# Altium schematic sheet PowerDistribution.SchDoc (continued)

## Library data of the parts
C9 C10 C17 = CMP-1036-04410-2: CAP CER 0.1UF 25V X7R 0805; Manufacturer: Yageo; Manufacturer Part Number: CC0805KRX7R8BB104; Capacitance: 100 nF; Insulation Resistance: 100GR; Material: Ceramic; Tolerance: 0.1; Value: 100 nF; Automotive: No; Case Code (Imperial): 0805; Case Code (Metric): 2012; Case/Package: 0805; Category: Cap; Component Kind: Standard; ComponentLink1Description: https://datasheet.ciiva.com/8034/70765-8034031.pdf?src-supplier=Element14; ComponentLink1URL: https://datasheet.ciiva.com/8034/70765-8034031.pdf?src-supplier=Element14; ComponentLink2Description: Datasheet; ComponentLink2URL: http://www.yageo.com/documents/recent/UPY-GPHC_Y5V_6.3V-to-50V_8.pdf; ComponentLink3Description: https://datasheet.ciiva.com/28450/1489800-28450789.pdf?src-supplier=Element14; ComponentLink3URL: https://datasheet.ciiva.com/28450/1489800-28450789.pdf?src-supplier=Element14; ComponentLink4Description: https://datasheet.ciiva.com/46430/1850775-46430166.pdf?src-supplier=Element14; ComponentLink4URL: https://datasheet.ciiva.com/46430/1850775-46430166.pdf?src-supplier=Element14; ComponentLink5Description: https://datasheet.ciiva.com/145/mlccorderingcode-145008.pdf?src-supplier=Digi-Key; ComponentLink5URL: https://datasheet.ciiva.com/145/mlccorderingcode-145008.pdf?src-supplier=Digi-Key; ComponentLink6Description: https://datasheet.ciiva.com/145/mlccorderingcode-145007.pdf?src-supplier=Digi-Key; ComponentLink6URL: https://datasheet.ciiva.com/145/mlccorderingcode-145007.pdf?src-supplier=Digi-Key; ComponentLink7Description: https://datasheet.ciiva.com/145/mlccorderingcode-145009.pdf?src-supplier=Digi-Key; ComponentLink7URL: https://datasheet.ciiva.com/145/mlccorderingcode-145009.pdf?src-supplier=Digi-Key; ComponentLink8Description: https://datasheet.ciiva.com/8034/1784427-8034030.pdf?src-supplier=Element14; ComponentLink8URL: https://datasheet.ciiva.com/8034/1784427-8034030.pdf?src-supplier=Element14; Depth: 1.25mm; Device Class L1: Passive Components; Device Class L2: Capacitors; Device Class L3: Ceramic Capacitors; Dielectric: X7R; Digikey Description: CAP CER 0.1UF 25V X7R 0805; Footprint Url: http://www.yageo.com/exep/pages/download/literatures/UPY-C_GEN_24.pdf; Halogen Free: Halogen Free; Height: 0.95mm; Ipc Land Pattern Name: CAPC200125X85; Lead Free: Yes; Length: 2mm; Library Name: Altium Content Vault; Max Operating Temperature: 125°C; Min Operating Temperature: -55°C; Mount: Surface Mount; Mouser Description: Multilayer Ceramic Capacitors MLCC - SMD/SMT 100nF 25V X7R 10%; Number of Pins: 2; Octopart: https://octopart.com/cc0805krx7r8bb104-yageo-39467766; Package: CC0805-0.85; Package Quantity: 4000; Packaging: Tape and Reel; Pricing 1: 1=0.11000, 10=0.07700, 50=0.04380, 100=0.03620, 500=0.02542, 1000=0.02104 (USD); Radiation Hardening: No; Reach SVHC Compliant: Yes; Resistor Type: Ceramic; RoHS: TRUE; RoHS Compliant: Yes; Series: CC; Stock 1: 1013369; Supplier 1: Digi-Key; Supplier Part Number 1: 311-1141-1-ND; Temperature: -30°C to +85°C; Temperature Characteristic: X7R; Temperature Range High: +85°C; Temperature Range Low: -30°C; Termination: SMD/SMT; Thickness: 0.033inch; Voltage Rating: 25 V; Voltage Rating (DC): 25V; Width: 1.25mm
C11 C12 C18 = CMP-08246-001076-1: Cap Ceramic 2.2uF 35V X7R 10% Pad SMD 0805 +125°C Automotive T/R; Manufacturer: TDK; Manufacturer Part Number: CGA4J1X7R1V225K125AC; Capacitance: 2.2uF; Case Code (Imperial): 0805; Case Code (Metric): 2012; Case/Package: 0805; Category: Ceramic Capacitors; Component Kind: Standard; ComponentLink1Description: https://datasheet.ciiva.com/24219/cga4j1x7r1v225k125ac-265990-24219578.pdf?src-supplier=Mouser; ComponentLink1URL: https://datasheet.ciiva.com/24219/cga4j1x7r1v225k125ac-265990-24219578.pdf?src-supplier=Mouser; Composition: Ceramic; Depth: 1.25mm; Dielectric: X7R; Height: 1.25mm; Length: 2mm; Library Name: Altium Content Vault; Max Operating Temperature: 125°C; Min Operating Temperature: -55°C; Mount: Surface Mount; Package Quantity: 1; Packaging: Tape and Reel; Pricing 1: 1=0.11700, 2000=0.11300, 4000=0.10900, 8000=0.10500, 12000=0.10000 (USD); Ratings: AEC-Q200; REACH SVHC: No SVHC; Reach SVHC Compliant: Yes; Resistor Type: Ceramic; RoHS: Yes; RoHS Compliant: Yes; Series: CGA; Stock 1: 22000; Supplier 1: Newark; Supplier Part Number 1: 73AH9978; Termination: SMD/SMT; Thickness: 0.057inch; Tolerance: 10%; Voltage Rating: 35V; Voltage Rating (DC): 35V; Weight: 0.000194oz; Width: 0.049inch
C13-C16 C19 C20 = CMP-2007-03222-3: Chip Multilayer Ceramic Capacitors for General Purpose, 0805, 22uF, X5R, 15%, 20%, 25V; Manufacturer: Murata; Manufacturer Part Number: GRM21BR61E226ME44L; Capacitance: 22uF; Case Code (Imperial): 0805; Case Code (Metric): 2012; Case/Package: 0805; Category: Ceramic Capacitors; Component Kind: Standard; ComponentLink1Description: http://uk.rs-online.com/webdocs/13d2/0900766b813d2ca1.pdf?src-supplier=Rs; ComponentLink1URL: http://uk.rs-online.com/webdocs/13d2/0900766b813d2ca1.pdf?src-supplier=Rs; Construction: Flat; Depth: 1.25mm; Dielectric: X5R; Height: 1.25mm; Lead Free: Lead Free; Length: 2mm; Library Name: Altium Content Vault; Material: Ceramic; Max Operating Temperature: 85°C; Min Operating Temperature: -55°C; Mount: Surface Mount; Package Quantity: 3000; Packaging: Tape and Reel; Pricing 1: 3000=0.06440, 6000=0.05980 (USD); REACH SVHC: No SVHC; Reach SVHC Compliant: Yes; Resistor Type: Ceramic; RoHS: Yes; RoHS Compliant: Yes; Series: GRM; Stock 1: 774048; Supplier 1: Arrow Electronics; Supplier Part Number 1: GRM21BR61E226ME44L; Termination: SMD/SMT; Thickness: 0.057inch; Tolerance: 20%; Voltage: 25V; Voltage Rating: 25V; Voltage Rating (DC): 25V; Weight: 0.000335oz; Width: 1.25mm
C21-C23 = CMP-12462-000012-1: CAP ALUM 100UF 20% 25V SMD; Manufacturer: Nichicon; Manufacturer Part Number: UWX1E101MCL1GB; Dielectric Material: Aluminium; Dissipation Factor: 0.14%; Lead Diameter: 0.8mm; Leakage Current: 3uA; Life (Hours): 2000Hour; Polarity: Polar; Ripple Current: 110mA; Capacitance: 100uF; Case/Package: Radial; Category: Aluminum Electrolytic Capacitors; Component Kind: Standard; ComponentLink1Description: https://datasheet.ciiva.com/11778/0900766b80fa3a90-11778418.pdf?src-supplier=Rs; ComponentLink1URL: https://datasheet.ciiva.com/11778/0900766b80fa3a90-11778418.pdf?src-supplier=Rs; ComponentLink2Description: https://datasheet.ciiva.com/96/e-wx-96650.pdf?src-supplier=Digi-Key; ComponentLink2URL: https://datasheet.ciiva.com/96/e-wx-96650.pdf?src-supplier=Digi-Key; ComponentLink3Description: https://datasheet.ciiva.com/96/e-wx-96651.pdf?src-supplier=Digi-Key; ComponentLink3URL: https://datasheet.ciiva.com/96/e-wx-96651.pdf?src-supplier=Digi-Key; ComponentLink4Description: https://datasheet.ciiva.com/96/e-wx-96652.pdf?src-supplier=Digi-Key; ComponentLink4URL: https://datasheet.ciiva.com/96/e-wx-96652.pdf?src-supplier=Digi-Key; ComponentLink5Description: https://datasheet.ciiva.com/11687/e-wx-11687148.pdf?src-supplier=Avnet; ComponentLink5URL: https://datasheet.ciiva.com/11687/e-wx-11687148.pdf?src-supplier=Avnet; ComponentLink6Description: https://datasheet.ciiva.com/5361/e-wx-11809-5361100.pdf?src-supplier=Mouser; ComponentLink6URL: https://datasheet.ciiva.com/5361/e-wx-11809-5361100.pdf?src-supplier=Mouser; ComponentLink7Description: https://datasheet.ciiva.com/16512/e-wx-16512638.pdf?src-supplier=Future+Electronics; ComponentLink7URL: https://datasheet.ciiva.com/16512/e-wx-16512638.pdf?src-supplier=Future+Electronics; ComponentLink8Description: https://datasheet.ciiva.com/28458/1912851-28458684.pdf?src-supplier=Element14; ComponentLink8URL: https://datasheet.ciiva.com/28458/1912851-28458684.pdf?src-supplier=Element14; Construction: Cylindrical; Diameter: 8mm; Height: 5.4mm; Height - Seated (Max): 0.213inch; Lead Free: Lead Free; Lead Pitch: 2.3mm; Length: 5.4mm; Library Name: Altium Content Vault; Max Operating Temperature: 85°C; Min Operating Temperature: -40°C; Mount: Surface Mount; Number of Pins: 2; Package Quantity: 1000; Packaging: Tape and Reel; Pricing 1: 1=0.53000, 10=0.36600, 50=0.25600, 100=0.21940, 500=0.16456 (USD); Radiation Hardening: No; REACH SVHC: No SVHC; Reach SVHC Compliant: Yes; Ripple Current (AC): 110mA; RoHS: Yes; RoHS Compliant: Yes; Series: WX; Stock 1: 54084; Supplier 1: Digi-Key; Termination: SMD/SMT; Tolerance: 20%; Voltage: 25V; Voltage Rating: 25V; Voltage Rating (DC): 25V; Weight: 0.01lb; Width: 0.25inch
D1 = CMP-1055-00557-1: Dual Series Cathode Schottky Barrier Diodes, 3-Pin SOT-323, Pb-Free, Tape and Reel; Manufacturer: On Semiconductor; Average Rectified Current: 200mA; Configuration: Common Cathode; Forward Voltage: 800mV; IFSM Max (A): 0.6; Max Forward Surge Current (Ifsm): 600mA; Max Repetitive Reverse Voltage (Vrrm): 30V; Max Reverse Current: 2uA; Power Dissipation: 200mW; Recovery Time: 5ns; trr Max (ns): 5; Case/Package: SOT-323; Category: Schottky Diodes; Component Kind: Standard; ComponentLink1Description: Manufacturer URL; ComponentLink1URL: http://www.onsemi.com/; ComponentLink2Description: Datasheet; ComponentLink2URL: http://www.onsemi.cn/pub_link/Collateral/BAT54CWT1-D.PDF; ComponentLink3Description: Package Specification; ComponentLink3URL: http://www.onsemi.com/pub_link/Collateral/419-04.PDF; ComponentLink4Description: https://datasheet.ciiva.com/905/bat54cwt1-d-905845.pdf?src-supplier=Digi-Key; ComponentLink4URL: https://datasheet.ciiva.com/905/bat54cwt1-d-905845.pdf?src-supplier=Digi-Key; ComponentLink5Description: https://datasheet.ciiva.com/28381/1708235-28381253.pdf?src-supplier=Element14; ComponentLink5URL: https://datasheet.ciiva.com/28381/1708235-28381253.pdf?src-supplier=Element14; ComponentLink6Description: https://datasheet.ciiva.com/22651/1706529-22651951.pdf?src-supplier=Element14; ComponentLink6URL: https://datasheet.ciiva.com/22651/1706529-22651951.pdf?src-supplier=Element14; ComponentLink7Description: https://datasheet.ciiva.com/4715/bat54cwt1g-102392-4715466.pdf?src-supplier=Mouser; ComponentLink7URL: https://datasheet.ciiva.com/4715/bat54cwt1g-102392-4715466.pdf?src-supplier=Mouser; ComponentLink8Description: https://datasheet.ciiva.com/4715/bat54cwt1-d-111181-4715156.pdf?src-supplier=Mouser; ComponentLink8URL: https://datasheet.ciiva.com/4715/bat54cwt1-d-111181-4715156.pdf?src-supplier=Mouser; ComponentLink9Description: https://datasheet.ciiva.com/22659/1708235-22659015.pdf?src-supplier=Element14; ComponentLink9URL: https://datasheet.ciiva.com/22659/1708235-22659015.pdf?src-supplier=Element14; ComponentLink10Description: https://datasheet.ciiva.com/8303/bat54cwt1g-8303708.pdf?src-supplier=Avnet; ComponentLink10URL: https://datasheet.ciiva.com/8303/bat54cwt1g-8303708.pdf?src-supplier=Avnet; ComponentLink11Description: https://datasheet.ciiva.com/8303/bat54cwt1g-8303706.pdf?src-supplier=Avnet; ComponentLink11URL: https://datasheet.ciiva.com/8303/bat54cwt1g-8303706.pdf?src-supplier=Avnet; ComponentLink12Description: https://datasheet.ciiva.com/6390/bat54cwt1g-6390474.pdf?src-supplier=Digi-Key; ComponentLink12URL: https://datasheet.ciiva.com/6390/bat54cwt1g-6390474.pdf?src-supplier=Digi-Key; ComponentLink13Description: https://datasheet.ciiva.com/905/bat54cwt1-d-905846.pdf?src-supplier=Digi-Key; ComponentLink13URL: https://datasheet.ciiva.com/905/bat54cwt1-d-905846.pdf?src-supplier=Digi-Key; ComponentLink14Description: https://datasheet.ciiva.com/905/bat54cwt1-d-905847.pdf?src-supplier=Digi-Key; ComponentLink14URL: https://datasheet.ciiva.com/905/bat54cwt1-d-905847.pdf?src-supplier=Digi-Key; Contact Plating: Tin,Matte; DatasheetVersion: Rev. 7; Element Configuration: Common Cathode; Forward Current: 200mA; Height: 0.9mm; Lead Free: Lead Free; Length: 2.2mm; Library Name: Altium Content Vault; Manufacturer Part Number: BAT54CWT1G; Max Operating Temperature: 125°C; Max Reverse Leakage Current: 2uA; Max Reverse Voltage (DC): 30V; Max Surge Current: 600mA; Min Operating Temperature: -65°C; Mount: Surface Mount; Mounting Technology: Surface Mount; Number of Pins: 3; Package Quantity: 1; PackageDescription: 3-Pin Small Outline Transistor, Body 2 x 1.25 mm, Pitch 0.65 mm; PackageReference: SOT-323-3-419-04; PackageVersion: Rev. N, 11/2008; Packaging: Tape and Reel; Packing: Tape and Reel; PartNumber: BAT54CWT1G; Peak Non-Repetitive Surge Current: 600mA; Pricing 1: 1=0.22000, 10=0.18300, 100=0.09730, 500=0.06400, 1000=0.04352 (USD); Radiation Hardening: No; Reach SVHC Compliant: Yes; Reverse Recovery Time: 5ns; RoHS: TRUE; RoHS Compliant: Yes; Series: -; Stock 1: 13988; Supplier 1: Digi-Key; Supplier Part Number 1: BAT54CWT1GOSCT-ND; Weight: 0.03g; Width: 1.35mm
DS1-DS3 = CMP-2000-05062-1: Chip LED, Green, 2.2 V, -40 to 85 degC, 2-Pin SMD, Pb-Free, Tape and Reel; Manufacturer: Avago; Bulb Size: 1.25mmx1.4mm; Dominant Wavelength: 572nm; External Depth: 0.8mm; External Length / Height: 2mm; External Width: 1.25mm; Forward Current If: 20mA; Forward Voltage: 2.2V; Forward Voltage VF Max: 2.6V; LED Type: Standard; Lens Shape: Rectangular; Light Area Height: 1mm; Luminous Intensity: 15mcd; Luminous Intensity Min: 4mcd; No. of Pins: 2; Opto Mounting Orientation: Top; Peak Wavelength: 570nm; PIV Max: 5V; SVHC: NoSVHC(16-Jun-2014); Viewing Angle: 170deg; Component Kind: Standard; Datasheet URL: https://datasheet.ciiva.com/29280/180495-da-01-en-chip-led-hsmg-c170-gelb-gruen-29280971.pdf; Datasheet Version: Oct-08; Dominant Wavelength Typ: 572nm; Forward Current If Max: 20mA; LED Colour: Green; LED Mounting: SMD; Library Name: Altium Content Vault; Luminous Intensity Typ: 15mcd; Manufacturer URL: http://www.avagotech.com/; Mounting Type: SMD; MSL: MSL2A-4weeks; Operating Temperature Max: 85degC; Operating Temperature Min: -30degC; Operating Temperature Range: -30degCto+85degC; Opto Case Style: SMD; Package Description: 2-Pin Surface Mount Device, Body 2 x 1.25 mm, Height 0.8 mm; Package Reference: HSMX-C170; Packaging: CutTape; Peak Forward Current: 20mA; Storage Temperature Max: 85degC; Storage Temperature Min: -40degC; Voltage Vf Typ: 2.2V; Wavelength Typ: 570nm
J10 = CMP-1502-00190-2: CONN PWR JACK 2.5X5.5MM SOLDER; Body Color: Black; Connector Type: Power; Current Rating: 5A; Gender: Male; Insulation Resistance: 100MR; Pin Diameter: 2.5mm; Shielding: Unshielded; Voltage Rating: 24V; Voltage Rating (DC): 20V; Color: Black; Component Kind: Standard; Contact Gender: Female; Contact Material: Copper Alloy; Contact Plating: Tin; Flammability Rating: UL94 V-0; Housing Material: Polyamide; Library Name: Altium Content Vault; Max Operating Temperature: 85°C; Min Operating Temperature: -40°C; Mount: Through Hole; Package Quantity: 500; Packaging: Bulk; RoHS Compliant: Yes; Series: WR-DC; Termination: Through Hole
L1 = CMP-14484-000773-1: SMD Inductors 22uH ±20% 0.13A 4.81Ω 0805; Manufacturer: Taiyo Yuden; Manufacturer Part Number: LBC2012T220M; Core Material: Ferrite; Current Rating: 130mA; DC Resistance (DCR): 3.7R; Self Resonant Frequency: 16MHz; Shielding: Unshielded; Test Frequency: 2.52MHz; Case Code (Imperial): 0805; Case Code (Metric): 2012; Case/Package: 0805; Category: Fixed Inductors; Component Kind: Standard; ComponentLink1Description: https://datasheet.ciiva.com/1064/wound01-e-1064368.pdf?src-supplier=Digi-Key; ComponentLink1URL: https://datasheet.ciiva.com/1064/wound01-e-1064368.pdf?src-supplier=Digi-Key; ComponentLink2Description: https://datasheet.ciiva.com/8500/lbc2012t220m-ss-8500138.pdf?src-supplier=Avnet; ComponentLink2URL: https://datasheet.ciiva.com/8500/lbc2012t220m-ss-8500138.pdf?src-supplier=Avnet; ComponentLink3Description: https://datasheet.ciiva.com/26872/getdatasheetpartid-638628-26872276.pdf?src-supplier=Verical; ComponentLink3URL: https://datasheet.ciiva.com/26872/getdatasheetpartid-638628-26872276.pdf?src-supplier=Verical; ComponentLink4Description: https://datasheet.ciiva.com/8002/1497259-8002026.pdf?src-supplier=Element14; ComponentLink4URL: https://datasheet.ciiva.com/8002/1497259-8002026.pdf?src-supplier=Element14; DC Current: 130mA; Depth: 1.45mm; Halogen Free: Halogen Free; Height: 1.25mm; Height - Seated (Max): 0.057inch; Inductance: 22uH; Inductance Tolerance: 20%; Lead Free: Lead Free; Lead Pitch: 1mm; Length: 0.079inch; Library Name: Altium Content Vault; Max DC Current: 130mA; Max Operating Temperature: 105°C; Military Standard: Not; Min Operating Temperature: -25°C; Mount: Surface Mount; Number of Pins: 2; Package Quantity: 3000; Packaging: Tape and Reel; Pricing 1: 1=0.17540, 10=0.12040, 25=0.11920, 50=0.11730, 100=0.09790, 250=0.09260, 500=0.08330 (USD); Radiation Hardening: No; Resistor Type: Wirewound; RoHS: Yes; RoHS Compliant: Yes; Series: LB; Stock 1: 728; Supplier 1: Arrow Electronics; Supplier Part Number 1: LBC2012T220M; Termination: SMD/SMT; Tolerance: 20%; Weight: 0.000194oz; Width: 0.049inch
L2 = CMP-07248-000032-1: FIXED IND 8.2UH 2A 180 MOHM SMD; Manufacturer: Bourns; Manufacturer Part Number: SRP4020TA-8R2M; Automotive Grade: Grade 0; Core Material: Carbonyl Powder; DC Resistance (DCR): 180mR; Inductance Tolerance: 20%; Max DC Current: 2.5A; Q Factor: 10; Self Resonant Frequency: 21MHz; Series Resistance: 180mΩ; Shielding: true; Test Frequency: 100kHz; Automotive: Yes; Case/Package: SMD/SMT; Category: Ind; Component Kind: Standard; ComponentLink1Description: https://datasheet.ciiva.com/46437/1839010-46437192.pdf?src-supplier=Element14; ComponentLink1URL: https://datasheet.ciiva.com/46437/1839010-46437192.pdf?src-supplier=Element14; ComponentLink2Description: Datasheet; ComponentLink2URL: https://www.bourns.com/docs/Product-Datasheets/SRP4020TA.pdf; ComponentLink3Description: https://datasheet.ciiva.com/46437/1839010-46437191.pdf?src-supplier=Element14; ComponentLink3URL: https://datasheet.ciiva.com/46437/1839010-46437191.pdf?src-supplier=Element14; Composition: Wirewound; Current Rating: 2A; Depth: 4.06mm; Device Class L1: Passive Components; Device Class L2: Inductors; Device Class L3: Fixed Inductors; Digikey Description: FIXED IND 8.2UH 2A 180 MOHM SMD; Height: 2.1mm; Height - Seated (Max): 0.079inch; Inductance: 8.2uH; Lead Free: Yes; Length: 0.175inch; Library Name: Altium Content Vault; Max Operating Temperature: 150°C; Min Operating Temperature: -40°C; Mount: Surface Mount; Mouser Description: Fixed Inductors 8.2uH 20% SMD 4020 AEC-Q200; Number of Pins: 2; Octopart: https://octopart.com/srp4020ta-8r2m-bourns-47300342; Package: SRP4020TA; Package Quantity: 3000; Packaging: Tape and Reel; Pricing 1: 1=1.35000, 10=1.25700, 25=1.16040, 50=0.96700, 100=0.90910, 250=0.81236, 500=0.69630, 1000=0.61893 (USD); Ratings: AEC-Q200; Reach SVHC Compliant: Yes; Resistor Type: Wirewound; Rms Current: 2A; RMS Current (Irms): 2A; RoHS: TRUE; RoHS Compliant: Yes; Saturation Current: 2.5A; Series: SRP4020TA; Stock 1: 13839; Supplier 1: Digi-Key; Supplier Part Number 1: SRP4020TA-8R2MCT-ND; Temperature: -40°C to +150°C; Temperature Range High: +150°C; Temperature Range Low: -40°C; Termination: SMD/SMT; Tolerance: 20%; Value: 8.2uH; Weight: 0.005291oz; Width: 4.06mm
L3 = CMP-14484-000421-1: SMD Inductors 10uH ±10% 0.15A 0.468Ω 0805; Manufacturer: Taiyo Yuden; Manufacturer Part Number: LBR2012T100K; Core Material: Ferrite; Current Rating: 150mA; DC Resistance (DCR): 360mR; Self Resonant Frequency: 32MHz; Shielding: Unshielded; Test Frequency: 2.52MHz; Case Code (Imperial): 0805; Case Code (Metric): 2012; Case/Package: 0805; Category: Fixed Inductors; Component Kind: Standard; ComponentLink1Description: https://datasheet.ciiva.com/28479/1521622-28479584.pdf?src-supplier=Element14; ComponentLink1URL: https://datasheet.ciiva.com/28479/1521622-28479584.pdf?src-supplier=Element14; ComponentLink2Description: https://datasheet.ciiva.com/1038/lbr2012t100k-ss-1038898.pdf?src-supplier=Digi-Key; ComponentLink2URL: https://datasheet.ciiva.com/1038/lbr2012t100k-ss-1038898.pdf?src-supplier=Digi-Key; ComponentLink3Description: https://datasheet.ciiva.com/3082/lbr2012t100k-ss-3082080.pdf?src-supplier=Avnet; ComponentLink3URL: https://datasheet.ciiva.com/3082/lbr2012t100k-ss-3082080.pdf?src-supplier=Avnet; ComponentLink4Description: https://datasheet.ciiva.com/15986/291lbr2012t100k-15986593.pdf?src-supplier=Onlinecomponents.com; ComponentLink4URL: https://datasheet.ciiva.com/15986/291lbr2012t100k-15986593.pdf?src-supplier=Onlinecomponents.com; DC Current: 150mA; Depth: 1.45mm; Halogen Free: Halogen Free; Height: 1.6mm; Height - Seated (Max): 0.057inch; Inductance: 10uH; Inductance Tolerance: 10%; Lead Free: Lead Free; Lead Pitch: 1mm; Length: 0.079inch; Library Name: Altium Content Vault; Max DC Current: 150mA; Max Operating Temperature: 105°C; Military Standard: Not; Min Operating Temperature: -40°C; Mount: Surface Mount; Package Quantity: 3000; Packaging: Tape and Reel; Pricing 1: 1=0.13000, 10=0.11400, 25=0.10080, 50=0.08580, 100=0.07580, 250=0.06944, 500=0.06312, 1000=0.05302 (USD); Radiation Hardening: No; Resistor Type: Wirewound; RoHS: Yes; RoHS Compliant: Yes; Series: LB; Stock 1: 225887; Supplier 1: Digi-Key; Supplier Part Number 1: 587-2045-1-ND; Termination: SMD/SMT; Tolerance: 10%; Weight: 0.000194oz; Width: 1.6mm
Q8 = CMP-2000-05111-1: N-Channel MOSFET Transistor, 8 V VGSS, 25 V VDSS, 0.68 A, -55 to 150 degC, 3-Pin SOT23, RoHS, Tape and Reel; Manufacturer: Fairchild; Channel Mode: Enhancement; Channel Type: N; Continuous Drain Current (ID): 680mA; Current: 12A; Drain to Source Breakdown Voltage: 25V; Drain to Source Resistance: 450mR; Element Configuration: Single; Fall Time: 8.5ns; Gate to Source Voltage (Vgs): 8V; Input Capacitance: 50pF; Max Power Dissipation: 350mW; Maximum Continuous Drain Current: 0.68A; Nominal Vgs: 800mV; Number of Elements: 1; Rad Hard: No; RDS-on: 450@4.5VmOhm; Turn-Off Delay Time: 17ns; Turn-On Delay Time: 3ns; Typical Fall Time: 13ns; Voltage: 20V; Case/Package: SOT-23; Category: MOSFET; Component Kind: Standard; ComponentLink1Description: https://datasheet.ciiva.com/13052/fdv303n-108963-13052385.pdf?src-supplier=Mouser; ComponentLink1URL: https://datasheet.ciiva.com/13052/fdv303n-108963-13052385.pdf?src-supplier=Mouser; ComponentLink2Description: https://datasheet.ciiva.com/34301/871092-34301626.pdf?src-supplier=Jameco; ComponentLink2URL: https://datasheet.ciiva.com/34301/871092-34301626.pdf?src-supplier=Jameco; ComponentLink3Description: https://datasheet.ciiva.com/22681/1845307-22681736.pdf?src-supplier=Element14; ComponentLink3URL: https://datasheet.ciiva.com/22681/1845307-22681736.pdf?src-supplier=Element14; ComponentLink4Description: https://datasheet.ciiva.com/8114/fdv303n-8114704.pdf?src-supplier=Avnet; ComponentLink4URL: https://datasheet.ciiva.com/8114/fdv303n-8114704.pdf?src-supplier=Avnet; ComponentLink5Description: https://datasheet.ciiva.com/15137/getdatasheetpartid-90649-15137711.pdf?src-supplier=Verical; ComponentLink5URL: https://datasheet.ciiva.com/15137/getdatasheetpartid-90649-15137711.pdf?src-supplier=Verical; ComponentLink6Description: https://datasheet.ciiva.com/6037/fdv303n-6037404.pdf?src-supplier=Farnell; ComponentLink6URL: https://datasheet.ciiva.com/6037/fdv303n-6037404.pdf?src-supplier=Farnell; ComponentLink7Description: https://datasheet.ciiva.com/6037/fdv303n-6037406.pdf?src-supplier=Farnell; ComponentLink7URL: https://datasheet.ciiva.com/6037/fdv303n-6037406.pdf?src-supplier=Farnell; ComponentLink8Description: https://datasheet.ciiva.com/14092/fdv303n-eng-tds-14092037.pdf?src-supplier=Distrelec; ComponentLink8URL: https://datasheet.ciiva.com/14092/fdv303n-eng-tds-14092037.pdf?src-supplier=Distrelec; ComponentLink9Description: https://datasheet.ciiva.com/16958/fdv303n-16958487.pdf?src-supplier=Future+Electronics; ComponentLink9URL: https://datasheet.ciiva.com/16958/fdv303n-16958487.pdf?src-supplier=Future+Electronics; ComponentLink10Description: https://datasheet.ciiva.com/8114/fdv303n-8114700.pdf?src-supplier=Avnet; ComponentLink10URL: https://datasheet.ciiva.com/8114/fdv303n-8114700.pdf?src-supplier=Avnet; ComponentLink11Description: https://datasheet.ciiva.com/931/fdv303n-931810.pdf?src-supplier=Digi-Key; ComponentLink11URL: https://datasheet.ciiva.com/931/fdv303n-931810.pdf?src-supplier=Digi-Key; ComponentLink12Description: https://datasheet.ciiva.com/931/fdv303n-931811.pdf?src-supplier=Digi-Key; ComponentLink12URL: https://datasheet.ciiva.com/931/fdv303n-931811.pdf?src-supplier=Digi-Key; ComponentLink13Description: https://datasheet.ciiva.com/931/fdv303n-931809.pdf?src-supplier=Digi-Key; ComponentLink13URL: https://datasheet.ciiva.com/931/fdv303n-931809.pdf?src-supplier=Digi-Key; Contact Plating: Tin,Matte; Current Rating: 680mA; Datasheet URL: https://datasheet.ciiva.com/22681/1845307-22681736.pdf; Datasheet Version: Rev. D2, 07/2014; Drain to Source Voltage (Vdss): 25V; Drain-source On Resistance-Max: 450mR; Dual Supply Voltage: 25V; Height: 0.93mm; Lead Free: Lead Free; Length: 2.92mm; Library Name: Altium Content Vault; Manufacturer Part Number: FDV303N; Manufacturer URL: https://www.fairchildsemi.com/; Max Operating Temperature: 150°C; Maximum Drain Source Voltage: 25V; Maximum Gate Source Voltage: 8V; Min Operating Temperature: -55°C; Mount: Surface Mount; Mounting: SurfaceMount; Number of Pins: 3; On-State Resistance: 450mR; Operating Temperature: -55to150degC; Package: 3SOT-23; Package Quantity: 3000; Packaging: Tape&Reel; Polarity: N-CHANNEL; Power Dissipation: 350mW; Pricing 1: 1=0.42000, 10=0.31400, 100=0.17770, 500=0.11766, 1000=0.09021 (USD); Radiation Hardening: No; Rds On Max: 450mR; Reach SVHC Compliant: Yes; Rise Time: 8.5ns; RoHS: Yes; RoHS Compliant: Yes; Series: -; Stock 1: 170049; Supplier 1: Digi-Key; Termination: SMD/SMT; Threshold Voltage: 800mV; Typical Rise Time: 8.5ns; Typical Turn-Off Delay Time: 17ns; Typical Turn-On Delay Time: 3ns; Voltage Rating (DC): 25V; Width: 3.05mm
R15 R16 R19 = CMP-2100-03668-5: RES 100K OHM 1% 1/8W 0805; Manufacturer: Yageo; Manufacturer Part Number: RC0805FR-07100KL; Max Power Dissipation: 125mW; Resistance: 100kR; Temperature Coefficient: 100ppm/°C; Voltage Rating: 150V; Case Code (Imperial): 0805; Case Code (Metric): 2012; Case/Package: 0805; Category: Chip SMD Resistors; Component Kind: Standard; Construction: Rectangular; Depth: 1.25mm; Features: Moisture Resistant; Height: 0.024inch; Lead Free: Lead Free; Lead Pitch: 0.4mm; Length: 0.079inch; Library Name: Altium Content Vault; Max Operating Temperature: 155°C; Military Standard: Not; Min Operating Temperature: -55°C; Number of Pins: 2; Number of Terminations: 2; Package Quantity: 1; Packaging: Tape and Reel; Power Rating: 125mW; Pricing 1: 1=0.10000, 10=0.03300, 100=0.01330, 1000=0.00600, 2500=0.00520 (USD); Resistance Tolerance: 1%; Resistor Type: Thick Film; RoHS: Yes; RoHS Compliant: Yes; Series: RC; Size Code: 0805; Stock 1: 5914890; Supplier 1: Digi-Key; Supplier Part Number 1: 311-100KCRCT-ND; Technology: THICK FILM; Termination: SMD/SMT; Tolerance: 1%; Voltage Rating (DC): 150V; Width: 0.049inch
R17 = CMP-2001-04027-2: RES Thick Film, 5.23kΩ, 1%, 0.125W, 100ppm/°C, 0805; Manufacturer: Vishay; Manufacturer Part Number: CRCW08055K23FKEA; Max Power Dissipation: 125mW; Resistance: 5.23kR; Voltage Rating: 150V; Case Code (Imperial): 0805; Case Code (Metric): 2012; Case/Package: 0805; Category: Chip SMD Resistors; Component Kind: Standard; ComponentLink1Description: https://datasheet.ciiva.com/2467/dcrcwe3-2467830.pdf?src-supplier=Digi-Key; ComponentLink1URL: https://datasheet.ciiva.com/2467/dcrcwe3-2467830.pdf?src-supplier=Digi-Key; ComponentLink2Description: https://datasheet.ciiva.com/2467/dcrcwe3-2467831.pdf?src-supplier=Digi-Key; ComponentLink2URL: https://datasheet.ciiva.com/2467/dcrcwe3-2467831.pdf?src-supplier=Digi-Key; ComponentLink3Description: https://datasheet.ciiva.com/2467/dcrcwe3-2467832.pdf?src-supplier=Digi-Key; ComponentLink3URL: https://datasheet.ciiva.com/2467/dcrcwe3-2467832.pdf?src-supplier=Digi-Key; ComponentLink4Description: https://datasheet.ciiva.com/9067/dcrcwe3-9067322.pdf?src-supplier=Avnet; ComponentLink4URL: https://datasheet.ciiva.com/9067/dcrcwe3-9067322.pdf?src-supplier=Avnet; ComponentLink5Description: https://datasheet.ciiva.com/9067/dcrcwe3-9067327.pdf?src-supplier=Avnet; ComponentLink5URL: https://datasheet.ciiva.com/9067/dcrcwe3-9067327.pdf?src-supplier=Avnet; ComponentLink6Description: https://datasheet.ciiva.com/7679/1697337-7679174.pdf?src-supplier=Element14; ComponentLink6URL: https://datasheet.ciiva.com/7679/1697337-7679174.pdf?src-supplier=Element14; ComponentLink7Description: https://datasheet.ciiva.com/7679/1697337-7679175.pdf?src-supplier=Element14; ComponentLink7URL: https://datasheet.ciiva.com/7679/1697337-7679175.pdf?src-supplier=Element14; ComponentLink8Description: https://datasheet.ciiva.com/16199/dcrcwe3-16199757.pdf?src-supplier=Future+Electronics; ComponentLink8URL: https://datasheet.ciiva.com/16199/dcrcwe3-16199757.pdf?src-supplier=Future+Electronics; ComponentLink9Description: https://datasheet.ciiva.com/22676/1847332-22676342.pdf?src-supplier=Element14; ComponentLink9URL: https://datasheet.ciiva.com/22676/1847332-22676342.pdf?src-supplier=Element14; ComponentLink10Description: https://datasheet.ciiva.com/22702/1697337-22702841.pdf?src-supplier=Element14; ComponentLink10URL: https://datasheet.ciiva.com/22702/1697337-22702841.pdf?src-supplier=Element14; ComponentLink11Description: https://datasheet.ciiva.com/7726/66915-7726028.pdf?src-supplier=Element14; ComponentLink11URL: https://datasheet.ciiva.com/7726/66915-7726028.pdf?src-supplier=Element14; Composition: Thick Film; Construction: Rectangular; Contact Plating: Tin; Depth: 1.25mm; Features: Automotive AEC-Q200; Height: 0.45mm; Lead Free: Lead Free; Length: 2mm; Library Name: Altium Content Vault; Max Operating Temperature: 155°C; Military Standard: Not; Min Operating Temperature: -55°C; Number of Pins: 2; Number of Terminations: 2; Package Quantity: 10; Packaging: Tape and Reel; Power Rating: 125mW; Pricing 1: 1=0.10000, 10=0.06700, 25=0.05400, 50=0.04100, 100=0.02800 (USD); Resistance Tolerance: 1%; Resistor Type: Thick Film; RoHS: Yes; RoHS Compliant: Yes; Series: CRCW; Stock 1: 14555; Supplier 1: Newark; Supplier Part Number 1: 27W4709; Technology: THICK FILM; Temperature Coefficient: 100ppm/°C; Termination: SMD/SMT; Tolerance: 1%; Voltage Rating (DC): 150V; Weight: 0.000194oz; Width: 1.25mm; Working Voltage: 150V
R18 = CMP-2001-00049-1: Manufacturer: Panasonic; Manufacturer Part Number: ERJ-6ENF2212V; Max Power Dissipation: 125mW; Resistance: 22.1kR; Resistance Tolerance: 1%; Temperature Coefficient: 100ppm/°C; Voltage Rating: 150V; Case Code (Imperial): 0805; Case Code (Metric): 2012; Case/Package: 0805; Category: Chip SMD Resistors; Component Kind: Standard; ComponentLink1Description: https://datasheet.ciiva.com/11821/0900766b80f80784-11821597.pdf?src-supplier=Rs; ComponentLink1URL: https://datasheet.ciiva.com/11821/0900766b80f80784-11821597.pdf?src-supplier=Rs; ComponentLink2Description: https://datasheet.ciiva.com/36526/1989172-36526235.pdf?src-supplier=Jameco; ComponentLink2URL: https://datasheet.ciiva.com/36526/1989172-36526235.pdf?src-supplier=Jameco; ComponentLink3Description: https://datasheet.ciiva.com/43460/426256-43460056.pdf?src-supplier=Farnell; ComponentLink3URL: https://datasheet.ciiva.com/43460/426256-43460056.pdf?src-supplier=Farnell; ComponentLink4Description: https://datasheet.ciiva.com/6064/426256-6064649.pdf?src-supplier=Farnell; ComponentLink4URL: https://datasheet.ciiva.com/6064/426256-6064649.pdf?src-supplier=Farnell; ComponentLink5Description: https://datasheet.ciiva.com/46377/426256-46377962.pdf?src-supplier=Element14; ComponentLink5URL: https://datasheet.ciiva.com/46377/426256-46377962.pdf?src-supplier=Element14; ComponentLink6Description: https://datasheet.ciiva.com/28693/1678782-28693597.pdf?src-supplier=Element14; ComponentLink6URL: https://datasheet.ciiva.com/28693/1678782-28693597.pdf?src-supplier=Element14; ComponentLink7Description: https://datasheet.ciiva.com/24158/aoa0000ce2-24158937.pdf?src-supplier=Mouser; ComponentLink7URL: https://datasheet.ciiva.com/24158/aoa0000ce2-24158937.pdf?src-supplier=Mouser; ComponentLink8Description: https://datasheet.ciiva.com/16724/70260748-16724650.pdf?src-supplier=Allied+Electronics; ComponentLink8URL: https://datasheet.ciiva.com/16724/70260748-16724650.pdf?src-supplier=Allied+Electronics; ComponentLink9Description: https://datasheet.ciiva.com/16782/70260748-16782763.pdf?src-supplier=Allied+Electronics; ComponentLink9URL: https://datasheet.ciiva.com/16782/70260748-16782763.pdf?src-supplier=Allied+Electronics; ComponentLink10Description: https://datasheet.ciiva.com/9191/aoa0000ce2-9191797.pdf?src-supplier=Avnet; ComponentLink10URL: https://datasheet.ciiva.com/9191/aoa0000ce2-9191797.pdf?src-supplier=Avnet; ComponentLink11Description: https://datasheet.ciiva.com/30201/aoa0000ce2-30201590.pdf?src-supplier=Onlinecomponents.com; ComponentLink11URL: https://datasheet.ciiva.com/30201/aoa0000ce2-30201590.pdf?src-supplier=Onlinecomponents.com; Construction: Rectangular; Contact Plating: Tin; Depth: 1.25mm; Features: Automotive AEC-Q200; Height: 0.6mm; Lead Free: Lead Free; Length: 2mm; Library Name: Altium Content Vault; Max Operating Temperature: 155°C; Military Standard: Not; Min Operating Temperature: -55°C; Mount: Surface Mount; Number of Terminations: 2; Package Quantity: 1; Packaging: Tape and Reel; Part Number: ERJ-6ENF2212V; Power Rating: 125mW; Pricing 1: 1=0.10000, 10=0.09200, 100=0.03540, 1000=0.01558, 2500=0.01345 (USD); Radiation Hardening: No; Reach SVHC Compliant: Yes; Resistor Type: Thick Film; RoHS: Yes; RoHS Compliant: Yes; Series: ERJ; Size Code: 0805; Stock 1: 158902; Supplier 1: Digi-Key; Supplier Part Number 1: P22.1KCCT-ND; Technology: THICK FILM; Termination: SMD/SMT; Tolerance: 1%; Voltage Rating (DC): 150V; Weight: 0.000194oz; Width: 1.2mm
R20 = CMP-1013-00152-1: 1M8 0.125W 5% 0805 (2012 Metric)  SMD; Manufacturer: Yageo; Manufacturer Part Number: RC0805JR-071M8L; Case-EIA: 0805; Case-Metric: 2012; Max Power Dissipation: 125mW; Resistance: 1.8MR; Temperature Coefficient: 100ppm/°C; Value: 1800000.00 Ohm; Voltage Rating: 150V; Case Code (Imperial): 0805; Case Code (Metric): 2012; Case/Package: 0805; Category: Chip SMD Resistors; Component Kind: Standard; ComponentLink1Description: https://datasheet.ciiva.com/2456/pyu-r-int-thick-7-2456358.pdf?src-supplier=Digi-Key; ComponentLink1URL: https://datasheet.ciiva.com/2456/pyu-r-int-thick-7-2456358.pdf?src-supplier=Digi-Key; ComponentLink2Description: https://datasheet.ciiva.com/2456/pyu-r-int-thick-7-2456359.pdf?src-supplier=Digi-Key; ComponentLink2URL: https://datasheet.ciiva.com/2456/pyu-r-int-thick-7-2456359.pdf?src-supplier=Digi-Key; ComponentLink3Description: https://datasheet.ciiva.com/2456/pyu-r-int-thick-7-2456357.pdf?src-supplier=Digi-Key; ComponentLink3URL: https://datasheet.ciiva.com/2456/pyu-r-int-thick-7-2456357.pdf?src-supplier=Digi-Key; Construction: Rectangular; Contact Plating: Tin,Matte; Depth: 1.25mm; Features: Moisture Resistant; Height: 0.024inch; Lead Free: Lead Free; Length: 2mm; Library Name: Altium Content Vault; Max Operating Temperature: 155°C; Military Standard: Not; Min Operating Temperature: -55°C; Number of Terminations: 2; Package Quantity: 1; Packaging: Tape and Reel; Power: 0.125 W; Power Rating: 125mW; Pricing 1: 1=0.10000, 10=0.02800, 100=0.01150, 1000=0.00517, 2500=0.00448 (USD); Radiation Hardening: No; Resistor Type: Thick Film; RoHS: Yes; RoHS Compliant: Yes; Series: RC; Size Code: 0805; Stock 1: 10723; Supplier 1: Digi-Key; Supplier Part Number 1: 311-1.8MARCT-ND; Technology: SMT; Termination: SMD/SMT; Tolerance: 5 %; Voltage Rating (DC): 150V; Width: 1.25mm
R21 = CMP-2001-04947-2: RES Thick Film, 13.7kΩ, 1%, 0.125W, 100ppm/°C, 0805; Manufacturer: Vishay; Manufacturer Part Number: CRCW080513K7FKEA; Max Power Dissipation: 125mW; Resistance: 13.7kR; Voltage Rating: 150V; Case Code (Imperial): 0805; Case Code (Metric): 2012; Case/Package: 0805; Category: Chip SMD Resistors; Component Kind: Standard; ComponentLink1Description: https://datasheet.ciiva.com/11810/0900766b80d98b26-11810040.pdf?src-supplier=Rs; ComponentLink1URL: https://datasheet.ciiva.com/11810/0900766b80d98b26-11810040.pdf?src-supplier=Rs; ComponentLink2Description: https://datasheet.ciiva.com/2467/dcrcwe3-2467845.pdf?src-supplier=Digi-Key; ComponentLink2URL: https://datasheet.ciiva.com/2467/dcrcwe3-2467845.pdf?src-supplier=Digi-Key; ComponentLink3Description: https://datasheet.ciiva.com/2467/dcrcwe3-2467846.pdf?src-supplier=Digi-Key; ComponentLink3URL: https://datasheet.ciiva.com/2467/dcrcwe3-2467846.pdf?src-supplier=Digi-Key; ComponentLink4Description: https://datasheet.ciiva.com/2467/dcrcwe3-2467847.pdf?src-supplier=Digi-Key; ComponentLink4URL: https://datasheet.ciiva.com/2467/dcrcwe3-2467847.pdf?src-supplier=Digi-Key; ComponentLink5Description: https://datasheet.ciiva.com/3258/dcrcwe3-3258107.pdf?src-supplier=Avnet; ComponentLink5URL: https://datasheet.ciiva.com/3258/dcrcwe3-3258107.pdf?src-supplier=Avnet; ComponentLink6Description: https://datasheet.ciiva.com/5543/dcrcwe3-109170-5543941.pdf?src-supplier=Mouser; ComponentLink6URL: https://datasheet.ciiva.com/5543/dcrcwe3-109170-5543941.pdf?src-supplier=Mouser; ComponentLink7Description: https://datasheet.ciiva.com/7663/1697337-7663928.pdf?src-supplier=Element14; ComponentLink7URL: https://datasheet.ciiva.com/7663/1697337-7663928.pdf?src-supplier=Element14; ComponentLink8Description: https://datasheet.ciiva.com/16396/dcrcw-16396310.pdf?src-supplier=Future+Electronics; ComponentLink8URL: https://datasheet.ciiva.com/16396/dcrcw-16396310.pdf?src-supplier=Future+Electronics; ComponentLink9Description: https://datasheet.ciiva.com/22675/1847332-22675191.pdf?src-supplier=Element14; ComponentLink9URL: https://datasheet.ciiva.com/22675/1847332-22675191.pdf?src-supplier=Element14; ComponentLink10Description: https://datasheet.ciiva.com/16778/70201119-16778860.pdf?src-supplier=Allied+Electronics; ComponentLink10URL: https://datasheet.ciiva.com/16778/70201119-16778860.pdf?src-supplier=Allied+Electronics; Composition: Thick Film; Construction: Rectangular; Contact Plating: Tin; Depth: 1.25mm; Features: Automotive AEC-Q200; Height: 0.45mm; Lead Free: Lead Free; Length: 2mm; Library Name: Altium Content Vault; Max Operating Temperature: 155°C; Military Standard: Not; Min Operating Temperature: -55°C; Number of Pins: 2; Number of Terminations: 2; Package Quantity: 10; Packaging: Tape and Reel; Power Rating: 125mW; Pricing 1: 1=0.10000, 10=0.06700, 100=0.02710, 1000=0.01219, 2500=0.01058 (USD); Radiation Hardening: No; Resistance Tolerance: 1%; Resistor Type: Thick Film; RoHS: Yes; RoHS Compliant: Yes; Series: CRCW; Size Code: 0805; Stock 1: 15384; Supplier 1: Digi-Key; Supplier Part Number 1: 541-13.7KCCT-ND; Technology: THICK FILM; Temperature Coefficient: 100ppm/°C; Termination: SMD/SMT; Tolerance: 1%; Voltage Rating (DC): 150V; Weight: 0.000194oz; Width: 0.049inch
R22 = CMP-08839-031856-1: Res Thick Film 0805 5.6MΩ 1% 0.125W ±100ppm/°C Automotive Paper T/R; Manufacturer: Rohm; Manufacturer Part Number: KTR10EZPF5604; Max Power Dissipation: 125mW; Resistance: 5.6MR; Voltage Rating: 400V; Case Code (Imperial): 0805; Case Code (Metric): 2012; Case/Package: 0805; Category: Chip SMD Resistors; Component Kind: Standard; ComponentLink1Description: https://datasheet.ciiva.com/14925/ktr-14925887.pdf?src-supplier=Digi-Key; ComponentLink1URL: https://datasheet.ciiva.com/14925/ktr-14925887.pdf?src-supplier=Digi-Key; ComponentLink2Description: https://datasheet.ciiva.com/14926/ktr-14926617.pdf?src-supplier=Digi-Key; ComponentLink2URL: https://datasheet.ciiva.com/14926/ktr-14926617.pdf?src-supplier=Digi-Key; Features: Automotive AEC-Q200; Height: 0.026inch; Length: 0.079inch; Library Name: Altium Content Vault; Max Operating Temperature: 155°C; Min Operating Temperature: -55°C; Number of Terminations: 2; Package Quantity: 5000; Packaging: Tape and Reel; Pricing 1: 1=0.24000, 10=0.20200, 100=0.07890, 1000=0.03305, 2500=0.03030 (USD); Resistance Tolerance: 1%; Resistor Type: Thick Film; RoHS: Yes; RoHS Compliant: Yes; Series: KTR; Stock 1: 2622; Supplier 1: Digi-Key; Supplier Part Number 1: RHM5.6MAHCT-ND; Temperature Coefficient: 100ppm/°C; Tolerance: 1%; Width: 0.049inch
R23-R25 = CMP-2001-03823-2: RES Thick Film, 330Ω, 1%, 0.125W, 100ppm/°C, 0805; Manufacturer: Vishay; Manufacturer Part Number: CRCW0805330RFKEA; Max Power Dissipation: 125mW; Resistance: 330R; Voltage Rating: 150V; Case Code (Imperial): 0805; Case Code (Metric): 2012; Case/Package: 0805; Category: Chip SMD Resistors; Component Kind: Standard; ComponentLink1Description: https://datasheet.ciiva.com/8944/dcrcwe3-8944773.pdf?src-supplier=Avnet; ComponentLink1URL: https://datasheet.ciiva.com/8944/dcrcwe3-8944773.pdf?src-supplier=Avnet; ComponentLink2Description: https://datasheet.ciiva.com/8944/dcrcwe3-8944776.pdf?src-supplier=Avnet; ComponentLink2URL: https://datasheet.ciiva.com/8944/dcrcwe3-8944776.pdf?src-supplier=Avnet; ComponentLink3Description: https://datasheet.ciiva.com/2495/dcrcwe3-2495803.pdf?src-supplier=Digi-Key; ComponentLink3URL: https://datasheet.ciiva.com/2495/dcrcwe3-2495803.pdf?src-supplier=Digi-Key; ComponentLink4Description: https://datasheet.ciiva.com/2495/dcrcwe3-2495804.pdf?src-supplier=Digi-Key; ComponentLink4URL: https://datasheet.ciiva.com/2495/dcrcwe3-2495804.pdf?src-supplier=Digi-Key; ComponentLink5Description: https://datasheet.ciiva.com/2495/dcrcwe3-2495805.pdf?src-supplier=Digi-Key; ComponentLink5URL: https://datasheet.ciiva.com/2495/dcrcwe3-2495805.pdf?src-supplier=Digi-Key; ComponentLink6Description: https://datasheet.ciiva.com/5545/dcrcwe3-109170-5545357.pdf?src-supplier=Mouser; ComponentLink6URL: https://datasheet.ciiva.com/5545/dcrcwe3-109170-5545357.pdf?src-supplier=Mouser; ComponentLink7Description: https://datasheet.ciiva.com/16769/70201119-16769852.pdf?src-supplier=Allied+Electronics; ComponentLink7URL: https://datasheet.ciiva.com/16769/70201119-16769852.pdf?src-supplier=Allied+Electronics; ComponentLink8Description: https://datasheet.ciiva.com/7777/1697337-7777390.pdf?src-supplier=Element14; ComponentLink8URL: https://datasheet.ciiva.com/7777/1697337-7777390.pdf?src-supplier=Element14; ComponentLink9Description: https://datasheet.ciiva.com/7777/1720486-7777573.pdf?src-supplier=Element14; ComponentLink9URL: https://datasheet.ciiva.com/7777/1720486-7777573.pdf?src-supplier=Element14; Composition: Thick Film; Construction: Rectangular; Contact Plating: Tin; Depth: 1.25mm; Features: Automotive AEC-Q200; Height: 0.02inch; Lead Free: Lead Free; Length: 2mm; Library Name: Altium Content Vault; Max Operating Temperature: 155°C; Military Standard: Not; Min Operating Temperature: -55°C; Mount: Surface Mount; Number of Terminations: 2; Package Quantity: 10; Packaging: Tape and Reel; Power Rating: 125mW; Pricing 1: 1=0.10000, 10=0.06700, 100=0.02710, 1000=0.01219, 2500=0.01058 (USD); Ratings: AEC-Q200; Resistance Tolerance: 1%; Resistor Type: Thick Film; RoHS: Yes; RoHS Compliant: Yes; Series: CRCW; Size Code: 0805; Stock 1: 1889; Supplier 1: Digi-Key; Supplier Part Number 1: 541-330CCT-ND; Temperature Coefficient: 100ppm/°C; Termination: SMD/SMT; Tolerance: 1%; Voltage Rating (DC): 150V; Weight: 0.000194oz; Width: 0.049inch
TP23-TP30 TP32 TP33 TP60 = CMP-1672-00008-1: Test Point, 1 Position SMD, RoHS, Tape and Reel; Manufacturer: Keystone Electronics; Body Material: Bronze; Plating: Silver; Category: Connectors; Component Kind: Standard; ComponentLink1Description: Manufacturer URL; ComponentLink1URL: http://www.keyelco.com/; ComponentLink2Description: Datasheet; ComponentLink2URL: http://www.keyelco.com/product-pdf.cfm?p=1357; ComponentLink3Description: https://datasheet.ciiva.com/16885/70182998-16885945.pdf?src-supplier=Allied+Electronics; ComponentLink3URL: https://datasheet.ciiva.com/16885/70182998-16885945.pdf?src-supplier=Allied+Electronics; ComponentLink4Description: https://datasheet.ciiva.com/14848/m60-2p61-14848437.pdf?src-supplier=Digi-Key; ComponentLink4URL: https://datasheet.ciiva.com/14848/m60-2p61-14848437.pdf?src-supplier=Digi-Key; ComponentLink5Description: https://datasheet.ciiva.com/14848/m60-2p61-14848438.pdf?src-supplier=Digi-Key; ComponentLink5URL: https://datasheet.ciiva.com/14848/m60-2p61-14848438.pdf?src-supplier=Digi-Key; ComponentLink6Description: https://datasheet.ciiva.com/14848/m60-2p61-14848439.pdf?src-supplier=Digi-Key; ComponentLink6URL: https://datasheet.ciiva.com/14848/m60-2p61-14848439.pdf?src-supplier=Digi-Key; ComponentLink7Description: https://datasheet.ciiva.com/16318/m60-2p61-16318395.pdf?src-supplier=Future+Electronics; ComponentLink7URL: https://datasheet.ciiva.com/16318/m60-2p61-16318395.pdf?src-supplier=Future+Electronics; Contact Material: Bronze; Contact Plating: Silver; DatasheetVersion: 03/2014; Height: 0.09inch; Lead Free: Lead Free; Library Name: Altium Content Vault; Manufacturer Part Number: 5019; Material: Bronze; Mount: Surface Mount; Mounting Technology: Surface Mount; Number of Pins: 1; Package Quantity: 1000; PackageDescription: 1-Pin Surface Mount Device, Body 3.81 x 2.03 mm; PackageReference: 5019; Packaging: Tape and Reel; Pins: 1; Pricing 1: 1=0.34000, 25=0.27360, 100=0.22340, 250=0.20064, 500=0.19152 (USD); Reach SVHC Compliant: Yes; RoHS: Yes; RoHS Compliant: Yes; RoHSCompliant: True; Series: 5019; Stock 1: 1009631; Supplier 1: Digi-Key; Supplier Part Number 1: 36-5019CT-ND; Termination: SMD/SMT
U3-U5 = LMR51420YDDCR: SIMPLE SWITCHER® power converter 4.5-V to 36-V, 2-A, synchronous buck with 40-µA IQ 6-SOT-23-THIN -40 to 150; Manufacturer: Texas Instruments; Category: Integrated Circuits (ICs); Component Kind: Standard; Library Name: CustomSchematics.SchLib; Manufacturer Part Number: LMR51420YDDCR; Pricing 1: 1=1.56000, 10=1.41000, 25=1.33000, 50=1.33000, 100=1.13000, 250=1.06000, 500=0.92800, 1000=0.77000, 3000=0.76900, 10000=0.76900 (USD); RoHS: No; Stock 1: 4911; Supplier 1: Mouser; Supplier Part Number 1: 595-LMR51420YDDCR
U6 U7 = CMP-0063-01156-3: Microprocessor Reset Circuits, 3-pin SOT-23, Pb-Free; Manufacturer: Texas Instruments; Manufacturer Part Number: LM810M3-4.63/NOPB; CompType: MiscPower; Max Output Current: 20mA; Max Power Dissipation: 320mW; Max Reset Threshold Voltage: 4.7V; Max Supply Voltage: 5.5V; Min Reset Threshold Voltage: 4.56V; Min Supply Voltage: 1V; Nominal Supply Current: 18uA; Number of Elements: 1; Output: TOTEM-POLE; PackageTopMarking: SEB*XTT; PowerWise: N; Quiescent Current: 15uA; Reset: Active High; Reset Timeout: 140ms; Watchdog Timer: No; Case/Package: SOT-23; Category: Voltage Supervisors; Component Kind: Standard; ComponentLink1Description: Datasheet URL; ComponentLink1URL: http://www.ti.com/general/docs/lit/getliterature.tsp?genericPartNumber=LM810&fileType=pdf; ComponentLink2Description: https://datasheet.ciiva.com/15105/getdatasheetpartid-628297-15105879.pdf?src-supplier=Verical; ComponentLink2URL: https://datasheet.ciiva.com/15105/getdatasheetpartid-628297-15105879.pdf?src-supplier=Verical; ComponentLink3Description: https://datasheet.ciiva.com/7632/1882576-7632300.pdf?src-supplier=Element14; ComponentLink3URL: https://datasheet.ciiva.com/7632/1882576-7632300.pdf?src-supplier=Element14; ComponentLink4Description: https://datasheet.ciiva.com/46386/1882576-46386811.pdf?src-supplier=Element14; ComponentLink4URL: https://datasheet.ciiva.com/46386/1882576-46386811.pdf?src-supplier=Element14; ComponentLink5Description: https://datasheet.ciiva.com/14207/lm810-14207070.pdf?src-supplier=Avnet; ComponentLink5URL: https://datasheet.ciiva.com/14207/lm810-14207070.pdf?src-supplier=Avnet; ComponentLink6Description: https://datasheet.ciiva.com/1981/lm809-1981546.pdf?src-supplier=Digi-Key; ComponentLink6URL: https://datasheet.ciiva.com/1981/lm809-1981546.pdf?src-supplier=Digi-Key; ComponentLink7Description: https://datasheet.ciiva.com/1981/lm809-1981547.pdf?src-supplier=Digi-Key; ComponentLink7URL: https://datasheet.ciiva.com/1981/lm809-1981547.pdf?src-supplier=Digi-Key; ComponentLink8Description: https://datasheet.ciiva.com/1981/lm809-1981545.pdf?src-supplier=Digi-Key; ComponentLink8URL: https://datasheet.ciiva.com/1981/lm809-1981545.pdf?src-supplier=Digi-Key; Height: 0.93mm; Lead Free: Lead Free; Length: 2.92mm; Library Name: Altium Content Vault; Max Operating Temperature: 105°C; Min Operating Temperature: -40°C; Mount: Surface Mount; Number of Pins: 3; Number of Voltages Monitored: 1; Operating Supply Current: 18uA; Overvoltage Threshold: 4.7V; Package Quantity: 1000; PackageDescription: Molded SOT-23, .115 x .051 x .037in Body, 3 Lead, .075 in Pitch; PackageReference: DBZ0003A; Packaging: Tape and Reel; PinCount: 3; Power Dissipation: 320mW; Pricing 1: 1=1.02000, 10=0.91000, 25=0.86360, 100=0.70930, 250=0.66304, 500=0.58596 (USD); Radiation Hardening: No; Reach SVHC Compliant: Yes; RoHS: Y; RoHS Compliant: Yes; Series: LM810; Stock 1: 8778; Supplier 1: Digi-Key; Supplier Part Number 1: LM810M3-4.63/NOPBCT-ND; Supply Current: 18uA; Threshold Voltage: 4.63V; Type: Simple Reset/Power-On Reset; Undervoltage Threshold: 4.56V; Width: 1.3mm
U8 = CMP-04919-000046-1: IC SUPERVISOR 1 CHANNEL SOT23-3; Manufacturer: Texas Instruments; Manufacturer Part Number: TPS3809K33DBVT; Max Output Current: 5mA; Max Power Dissipation: 437mW; Max Reset Threshold Voltage: 2.99V; Max Supply Current: 25uA; Max Supply Voltage: 6V; Min Reset Threshold Voltage: 2.87V; Min Supply Voltage: 2V; Number of Elements: 1; Operating Supply Current: 9uA; Operating Supply Voltage: 3.3V; Output: TOTEM-POLE; Output Type: PUSH-PULL; Reset: Active Low; Reset Timeout: 120ms; Watchdog Timer: No; Case/Package: SOT-23; Category: Voltage Supervisors; Component Kind: Standard; ComponentLink1Description: https://datasheet.ciiva.com/34301/828553-34301572.pdf?src-supplier=Jameco; ComponentLink1URL: https://datasheet.ciiva.com/34301/828553-34301572.pdf?src-supplier=Jameco; ComponentLink2Description: https://datasheet.ciiva.com/14200/tps3809l30-14200560.pdf?src-supplier=Avnet; ComponentLink2URL: https://datasheet.ciiva.com/14200/tps3809l30-14200560.pdf?src-supplier=Avnet; ComponentLink3Description: https://datasheet.ciiva.com/22710/1830945-22710251.pdf?src-supplier=Element14; ComponentLink3URL: https://datasheet.ciiva.com/22710/1830945-22710251.pdf?src-supplier=Element14; ComponentLink4Description: https://datasheet.ciiva.com/1970/tps3809i50-1970523.pdf?src-supplier=Digi-Key; ComponentLink4URL: https://datasheet.ciiva.com/1970/tps3809i50-1970523.pdf?src-supplier=Digi-Key; ComponentLink5Description: https://datasheet.ciiva.com/1970/tps3809i50-1970522.pdf?src-supplier=Digi-Key; ComponentLink5URL: https://datasheet.ciiva.com/1970/tps3809i50-1970522.pdf?src-supplier=Digi-Key; ComponentLink6Description: https://datasheet.ciiva.com/1970/tps3809i50-1970524.pdf?src-supplier=Digi-Key; ComponentLink6URL: https://datasheet.ciiva.com/1970/tps3809i50-1970524.pdf?src-supplier=Digi-Key; ComponentLink7Description: https://datasheet.ciiva.com/28656/1882688-28656710.pdf?src-supplier=Element14; ComponentLink7URL: https://datasheet.ciiva.com/28656/1882688-28656710.pdf?src-supplier=Element14; ComponentLink8Description: https://datasheet.ciiva.com/15104/getdatasheetpartid-239223-15104983.pdf?src-supplier=Verical; ComponentLink8URL: https://datasheet.ciiva.com/15104/getdatasheetpartid-239223-15104983.pdf?src-supplier=Verical; Height: 1.15mm; Lead Free: Lead Free; Length: 2.9mm; Library Name: Altium Content Vault; Max Operating Temperature: 85°C; Min Operating Temperature: -40°C; Mount: Surface Mount; Nominal Supply Current: 25uA; Number of Pins: 3; Number of Voltages Monitored: 1; Overvoltage Threshold: 2.99V; Package Quantity: 250; Packaging: Tape and Reel; Power Dissipation: 437mW; Pricing 1: 1=1.16000, 10=1.03500, 25=0.98240, 100=0.80710 (USD); Quiescent Current: 9uA; Radiation Hardening: No; REACH SVHC: No SVHC; Reach SVHC Compliant: Yes; RoHS: Yes; RoHS Compliant: Yes; Series: -; Stock 1: 1765; Supplier 1: Digi-Key; Supplier Part Number 1: 296-2631-1-ND; Supply Current: 25uA; Termination: SMD/SMT; Threshold Voltage: 2.93V; Type: Simple Reset/Power-On Reset; Undervoltage Threshold: 2.93V; Width: 1.6mm
U9 = XT60: XT60 Connectors Male/female Pair; Manufacturer: SparkFun; Manufacturer Part Number: PRT-10474; Category: Headers and Wire Housings; Component Kind: Standard; ComponentLink1Description: https://cdn.sparkfun.com/datasheets/Prototyping/xt60.pdf?src-supplier=Digi-Key; ComponentLink1URL: https://cdn.sparkfun.com/datasheets/Prototyping/xt60.pdf?src-supplier=Digi-Key; Library Name: CustomSchematics.SchLib; Pricing 1: 1=1.50000, 10=1.50000, 50=1.50000, 100=1.50000, 1000=1.50000, 10000=1.50000 (USD); RoHS: No; Stock 1: 1468; Supplier 1: Mouser
